# Altium schematic sheet AEON.Feeder.BeamBreak.SchDoc
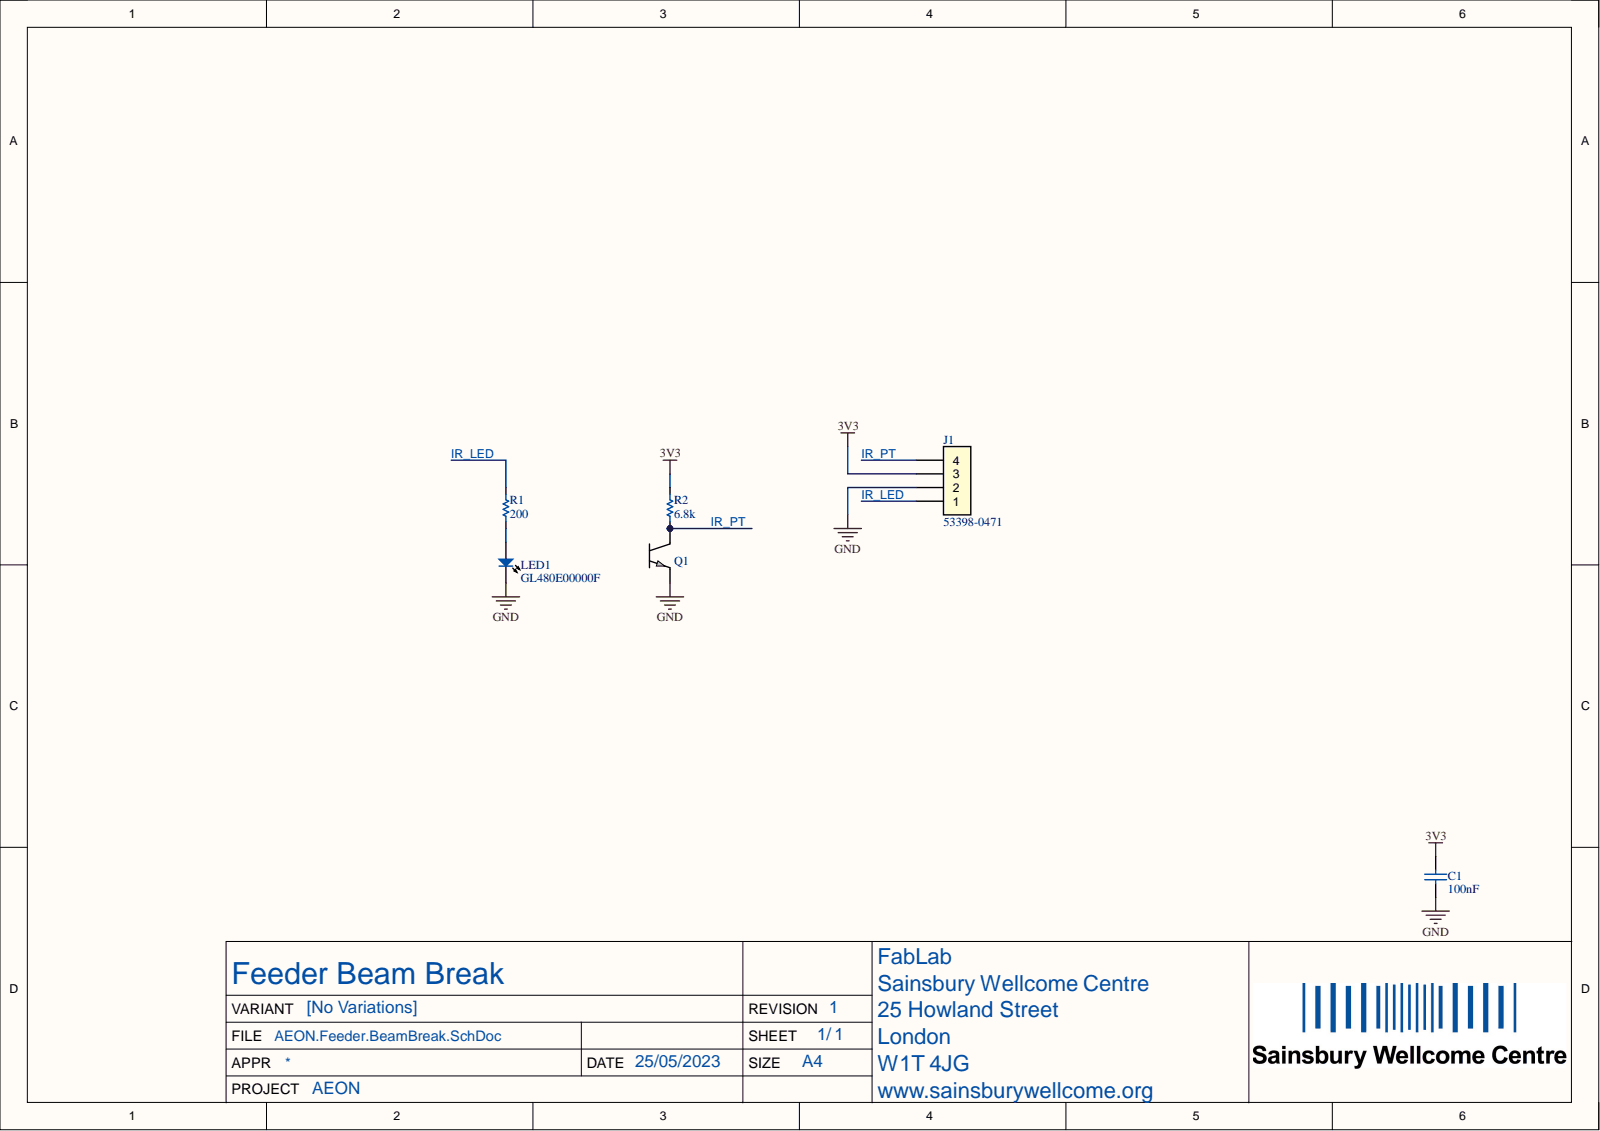
[diagram p0: AEON.Feeder.BeamBreak.SchDoc]

## Parts
C1  value 100nF  Capacitance: 100nF  [FP-GRM188-0_1-e0_2_0_5-IPC_B]  (hidden comment: C0603-100nF-100V-10%-X7R)
J1  53398-0471  [FP-533980471-MFG]
LED1  GL480E00000F  [GL480_5.8mm]
Q1  PT480FE0000F  [PT480_5.8]  (value not drawn)
R1  value 200  [RES_ERA6A_0805_PAN]  (hidden comment: RES 0805 200R 0.1% 125mW)
R2  value 6.8k  Resistance: 6.8k  [FP-CPF0603-IPC_B]  (hidden comment: R0603-6k8-1/16W-0.1%)

## Nets
3V3: C1.2 J1.3 R2.2
GND: C1.1 J1.2 LED1.1 Q1.1
IR_LED: J1.1 R1.2
IR_PT: J1.4 Q1.2 R2.1
NetLED1_2: LED1.2 R1.1

## Library data of the parts
C1 = CMP-611-0027-19: 0.1µF ±10% 100V Ceramic Capacitor X7R 0603 (1608 Metric); Pick&PlaceFootprint: 0603_CAP; Capacitor Type: Ceramic; Case Code (Imperial): 0603; Case Code (Metric): 1608; Case/Package: 0603; Component Kind: Standard; Construction: Flat; Depth: 0.8mm; Dielectric: X7R; Height: 0.8mm; Lead Free: Lead Free; Length: 1.6mm; Material: Ceramic; Max Operating Temperature: 125°C; Min Operating Temperature: -55°C; Mount: Surface Mount; Package Quantity: 1; Packaging: Tape and Reel; Radiation Hardening: No; REACH SVHC: No SVHC; RoHS: Yes; RoHS Compliant: Yes; Series: GRM; Size: 0.8mm; Termination: SMD/SMT; Thickness: 0.035inch; Tolerance: 10%; Voltage: 100V; Voltage Rating: 100V; Voltage Rating (DC): 100V; Weight: 0.000222oz; Width: 0.8mm; Library Name: Sainsbury Wellcome Centre
J1 = CMP-370-0030-2: Board Connector, 4 Contact(s), 1 Row(s), Male, Straight, 0.049 inch Pitch, Surface Mount Terminal, Locking, Natural Insulator, Receptacle; Board Mounting Option: FITTING NAIL; Body Breadth: 0.146inch; Body Depth: 0.185inch; Body Length: 0.383inch; Contact Pattern: RECTANGULAR; Contact Resistance: 20mR; Contact Style: Blade Pin; Dielectric Withstanding Voltage (AC): 250V; DIN Conformance: No; Durability: 30Cycles; Insulation Resistance: 100MR; Mating Information: MULTIPLE MATING PARTS AVAILABLE; Number Of Connectors: 1; Number of Contacts: 4; Option: General Purpose; Plating Thickness: 39uin; Polarization Key: POLARIZED HOUSING; Rated Current (Signal): 1A; Reference Standard: UL; Reliability: COMMERCIAL; Body/Shell Style: RECEPTACLE; Component Kind: Standard; Connector Type: BOARD CONNECTOR; Contact Finish - Mating: MATTE TIN (39) OVER NICKEL; Contact Finish - Termination: Matte Tin (Sn) - with Nickel (Ni) barrier; Contact Gender: Male; Contact Material: Bronze; Filter Feature: No; Flammability Rating: UL94 V-0; IEC Conformance: No; Insulation Color: Natural; Insulation Material: Polyamide; JESD-609 Code: e3; Mating Contact Pitch: 0.049inch; Max Operating Temperature: 85°C; MIL Conformance: No; Min Operating Temperature: -40°C; Mixed Contacts: No; Mount: Board; Mounting Option 1: LOCKING; Mounting Style: STRAIGHT; Number Of PCB Rows: 1; Number of Rows Loaded: 1; PartNumber: 53398-0471; PCB Contact Pattern: RECTANGULAR; REACH SVHC: Yes; RoHS Compliant: Yes; Terminal Pitch: 1.25mm; Termination: SMD/SMT; Library Name: Sainsbury Wellcome Centre
LED1 = CMP-520-0009-6: EMITTER IR 950NM 50MA RADIAL; Component Kind: Standard; Mount: Through Hole; PartNumber: GL480E00000F; Library Name: Sainsbury Wellcome Centre
Q1 = CMP-500-0000-5: SHARP PT480FE0000F PHOTOTRANSISTOR; Component Kind: Standard; Mount: Through Hole; PartNumber: PT480FE0000F; Library Name: Sainsbury Wellcome Centre
R1 = CMP-633-0043-2: RES 0805 200 Ohm 0.1% 1/8W ±10ppm/°C Thin Film; Pick&PlaceFootprint: R0805; Voltage Rating: 100V; Case/Package: R0805; Component Kind: Standard; Mount: Surface Mount; PartNumber: RES 0805 200R 0.1% 125mW; Power Rating: 125mW; Resistance: 200; RoHS: Yes; Tolerance: 0.1%; Library Name: Sainsbury Wellcome Centre
R2 = CMP-633-0025-15: Surface Mount Resistor 6.8k Ohm 1/16 W 0.1% 0603 (1608 Metric); Max Power Dissipation: 62.5 mW; Number of Resistors: 1; Pick&PlaceFootprint: R0603; Power Rating: 62.5mW; Temperature Coefficient: 25ppm/°C; Voltage Rating: 50V; Case Code (Imperial): 0603; Case Code (Metric): 1608; Case/Package: 0603; Component Kind: Standard; Composition: Thin Film; ELV: Compliant; Height: 0.45mm; Length: 1.6mm; Max Operating Temperature: 155°C; Min Operating Temperature: -55°C; Mount: Surface Mount; Number of Pins: 2; Number of Terminations: 2; Packaging: Tape and Reel; REACH SVHC: No SVHC; Resistance Tolerance: 0.1%; Resistor Type: Thin Film; RoHS: Yes; RoHS Compliant: Yes; Series: CPF; Size Code: 0603; Technology: Thin Film; Termination: Solder; Tolerance: 0.1%; Voltage Rating (DC): 50V; Weight: 7.1E-05oz; Width: 0.8mm; Library Name: Sainsbury Wellcome Centre
